# Altium schematic sheet flex.SchDoc
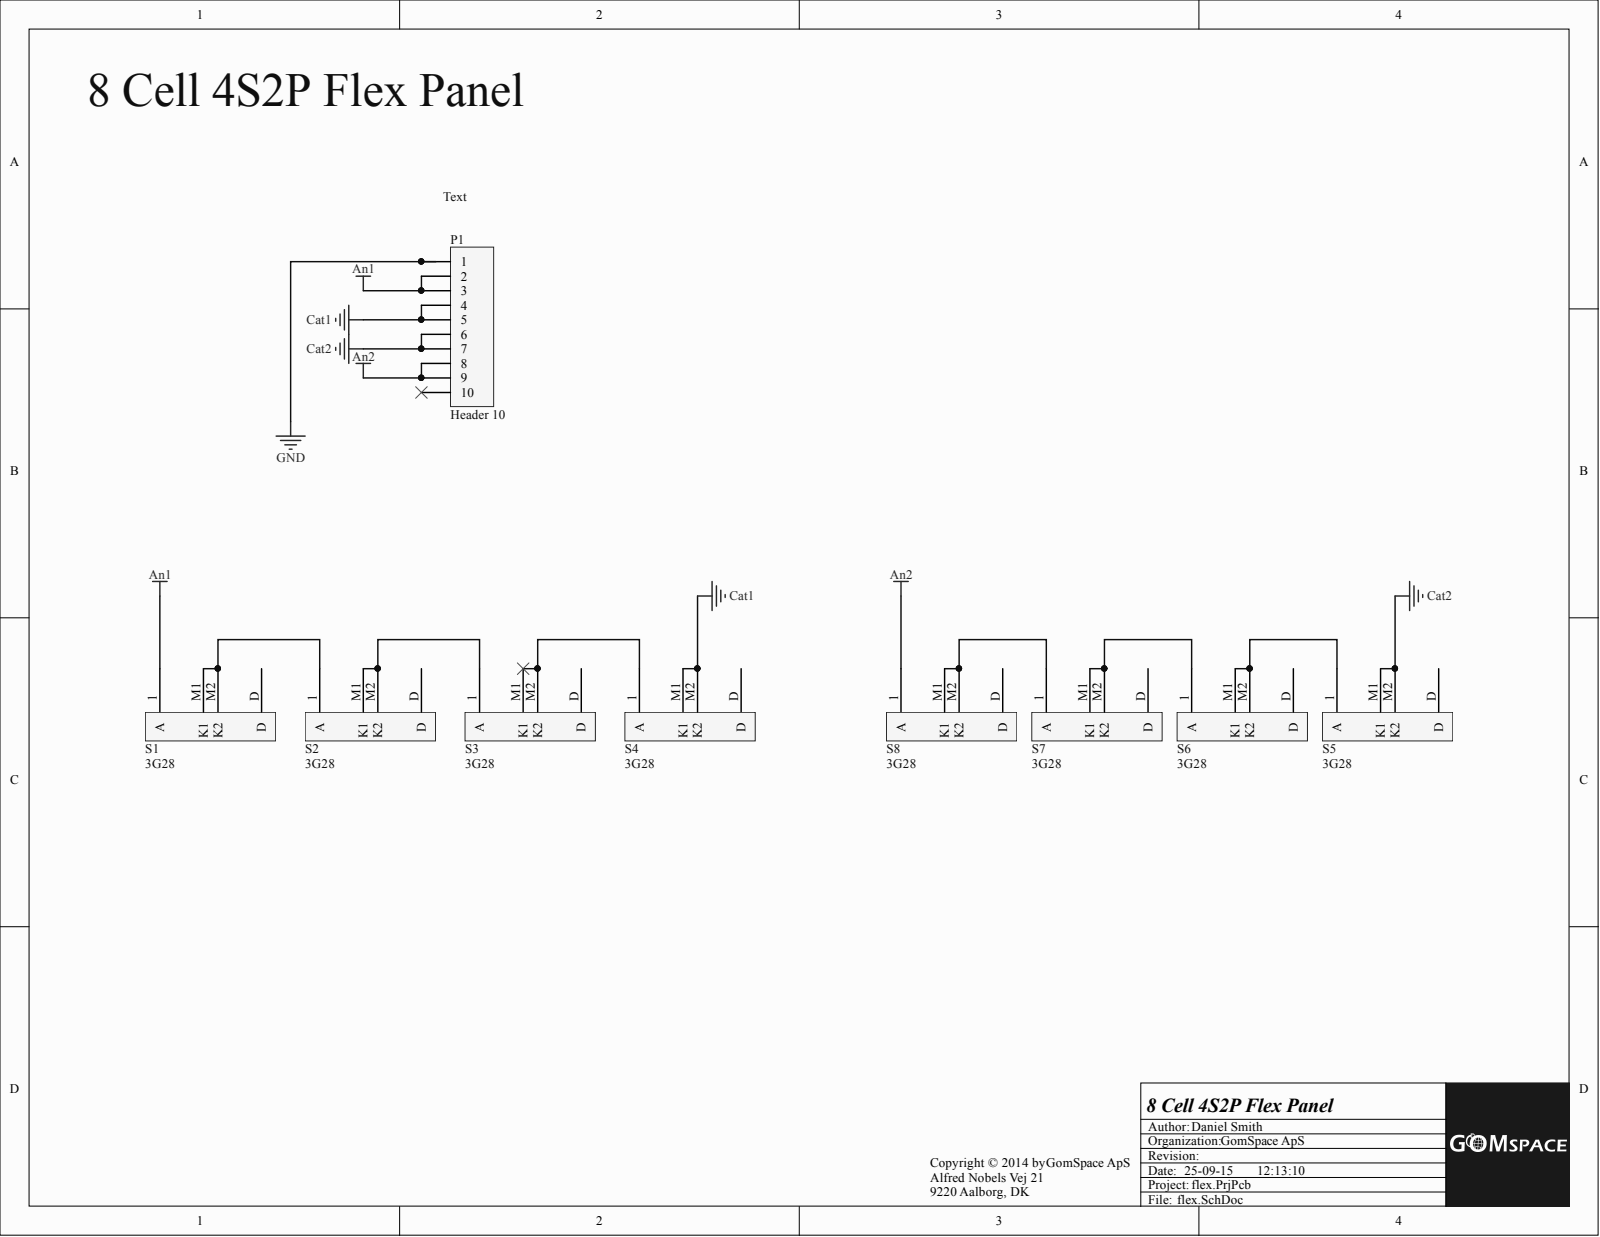
[diagram p0: flex.SchDoc]

## Parts
P1  Header 10  [FPC-10POS-1MM]
S1  3G28  [3G28-SEAM1]
S2  3G28  [3G30C-plain]
S3  3G28  [3G28-SEAM3]
S4  3G28  [3G28-SEAM4]
S5  3G28  [3G28-SEAM5]
S6  3G28  [3G30C-plain]
S7  3G28  [3G30C-plain]
S8  3G28  [3G28-SEAM8]

## Nets
AN1: P1.2 P1.3 S1.1(A)
AN2: P1.8 P1.9 S8.1(A)
CAT1: P1.4 P1.5 S4.M1(K1) S4.M2(K2)
CAT2: P1.6 P1.7 S5.M1(K1) S5.M2(K2)
GND: P1.1
NetS1_M1: S1.M1(K1) S1.M2(K2) S2.1(A)
NetS2_M1: S2.M1(K1) S2.M2(K2) S3.1(A)
NetS3_M1: S3.M1(K1) S3.M2(K2) S4.1(A)
NetS5_1: S5.1(A) S6.M1(K1) S6.M2(K2)
NetS6_1: S6.1(A) S7.M1(K1) S7.M2(K2)
NetS7_1: S7.1(A) S8.M1(K1) S8.M2(K2)

Not connected: P1 10; S1 D; S2 D; S3 D; S4 D; S5 D; S6 D; S7 D; S8 D

## Library data of the parts
P1 = Header 10: Header, 10-Pin; Component Kind: Standard; LatestRevisionDate: 17-Jul-2002; LatestRevisionNote: Re-released for DXP Platform.; Library Name: Miscellaneous Connectors.IntLib; Signal Integrity: Connector; Publisher: Altium Limited
S1-S8 = 3G28: Component Kind: Standard; Library Name: Schlib1.SchLib
